# Altium schematic sheet NU-PROG_ESP32_Panel.SchDoc(NU-PROG_ESP32_Panel)
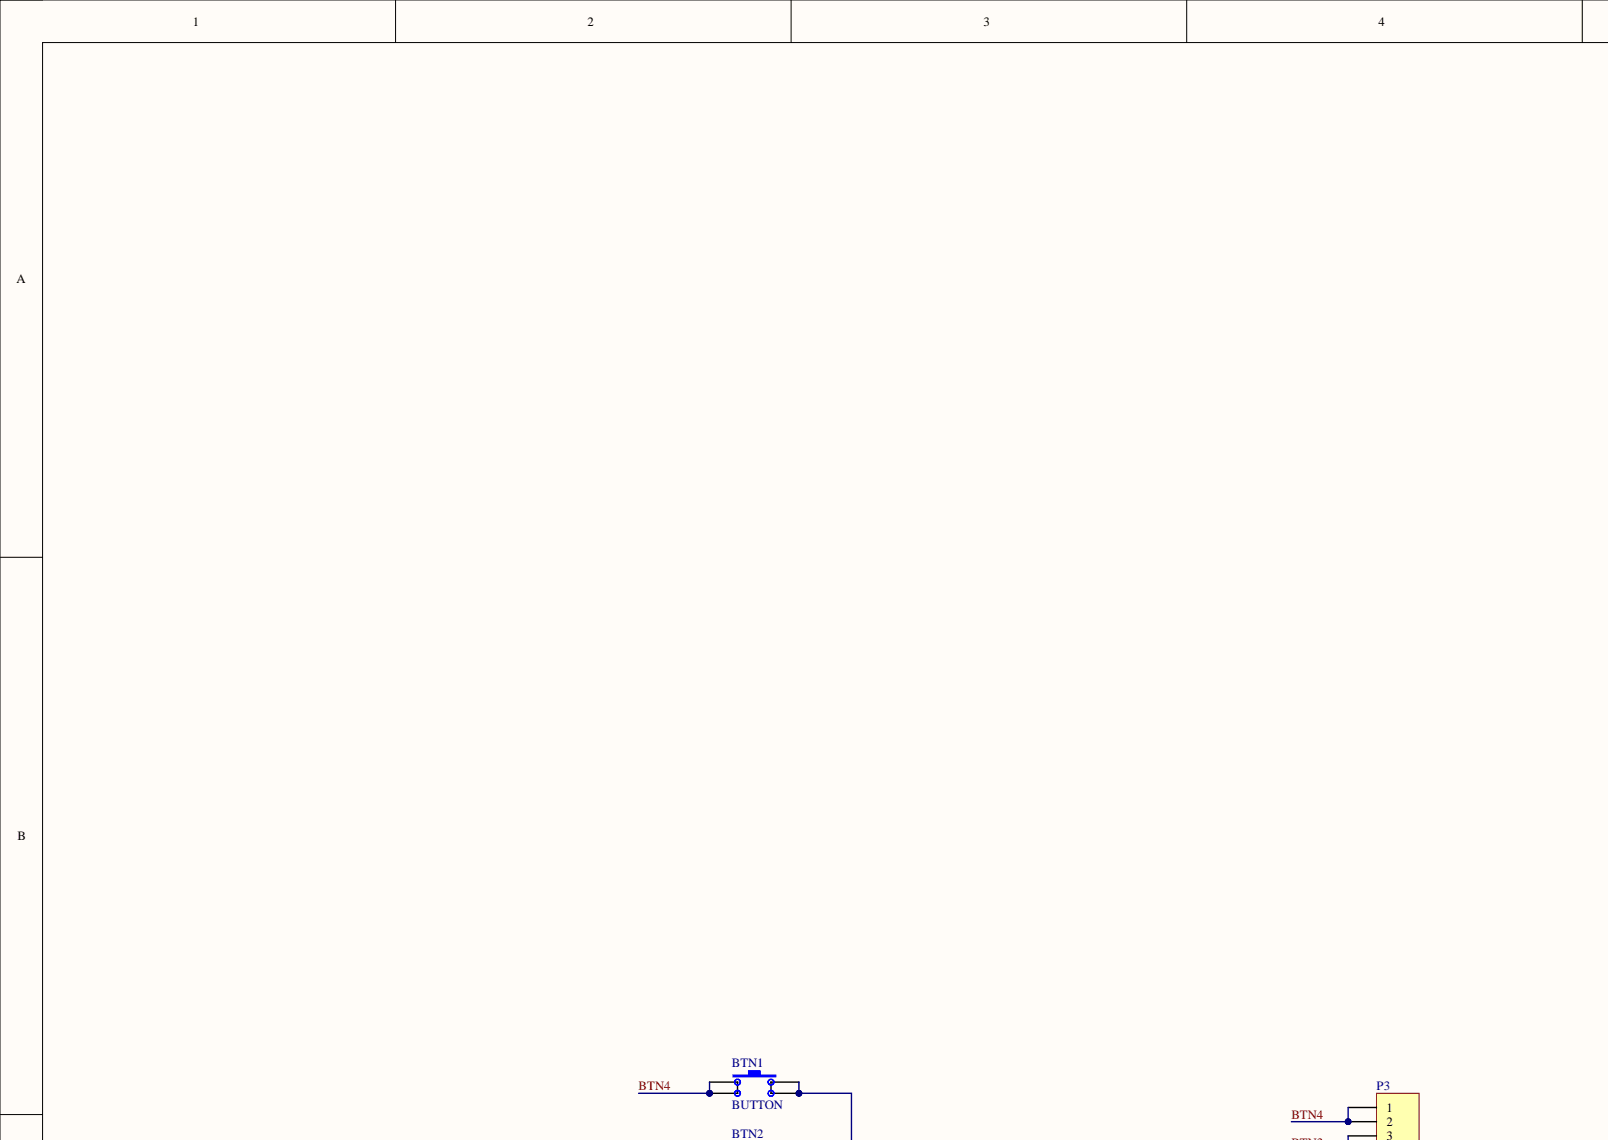
[diagram p0: NU-PROG_ESP32_Panel.SchDoc, top left]
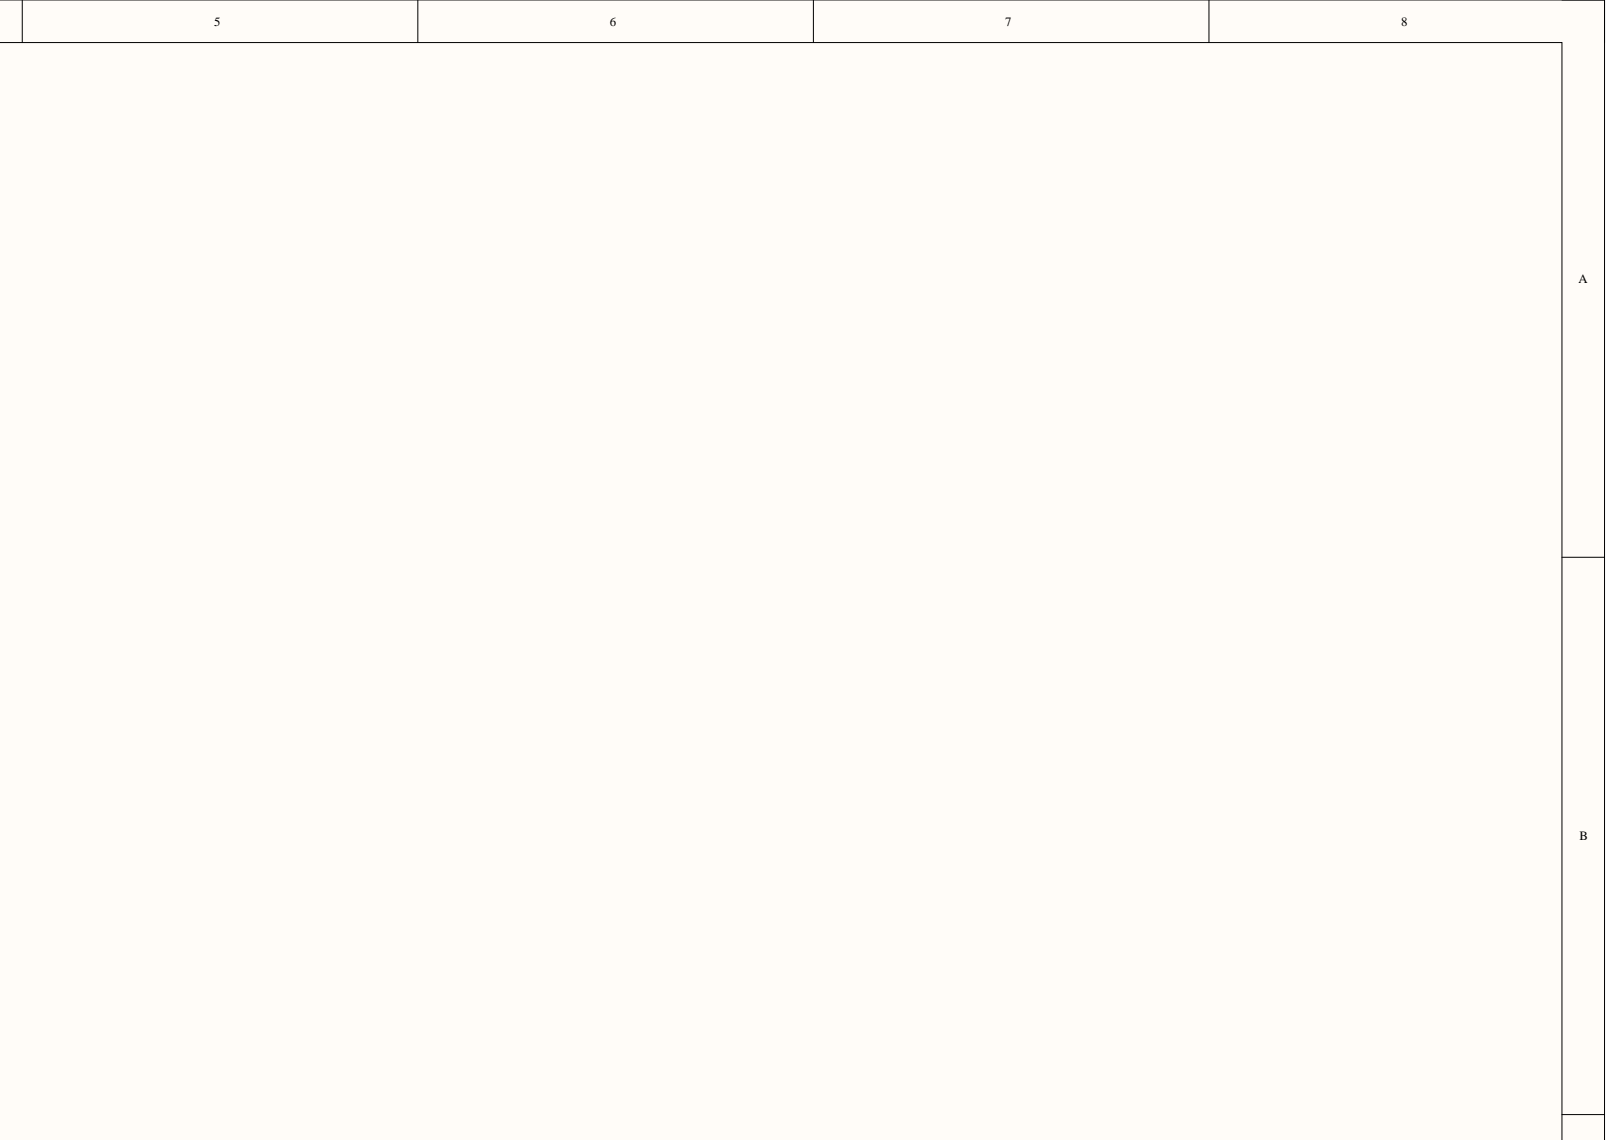
[diagram p1: NU-PROG_ESP32_Panel.SchDoc, top right]
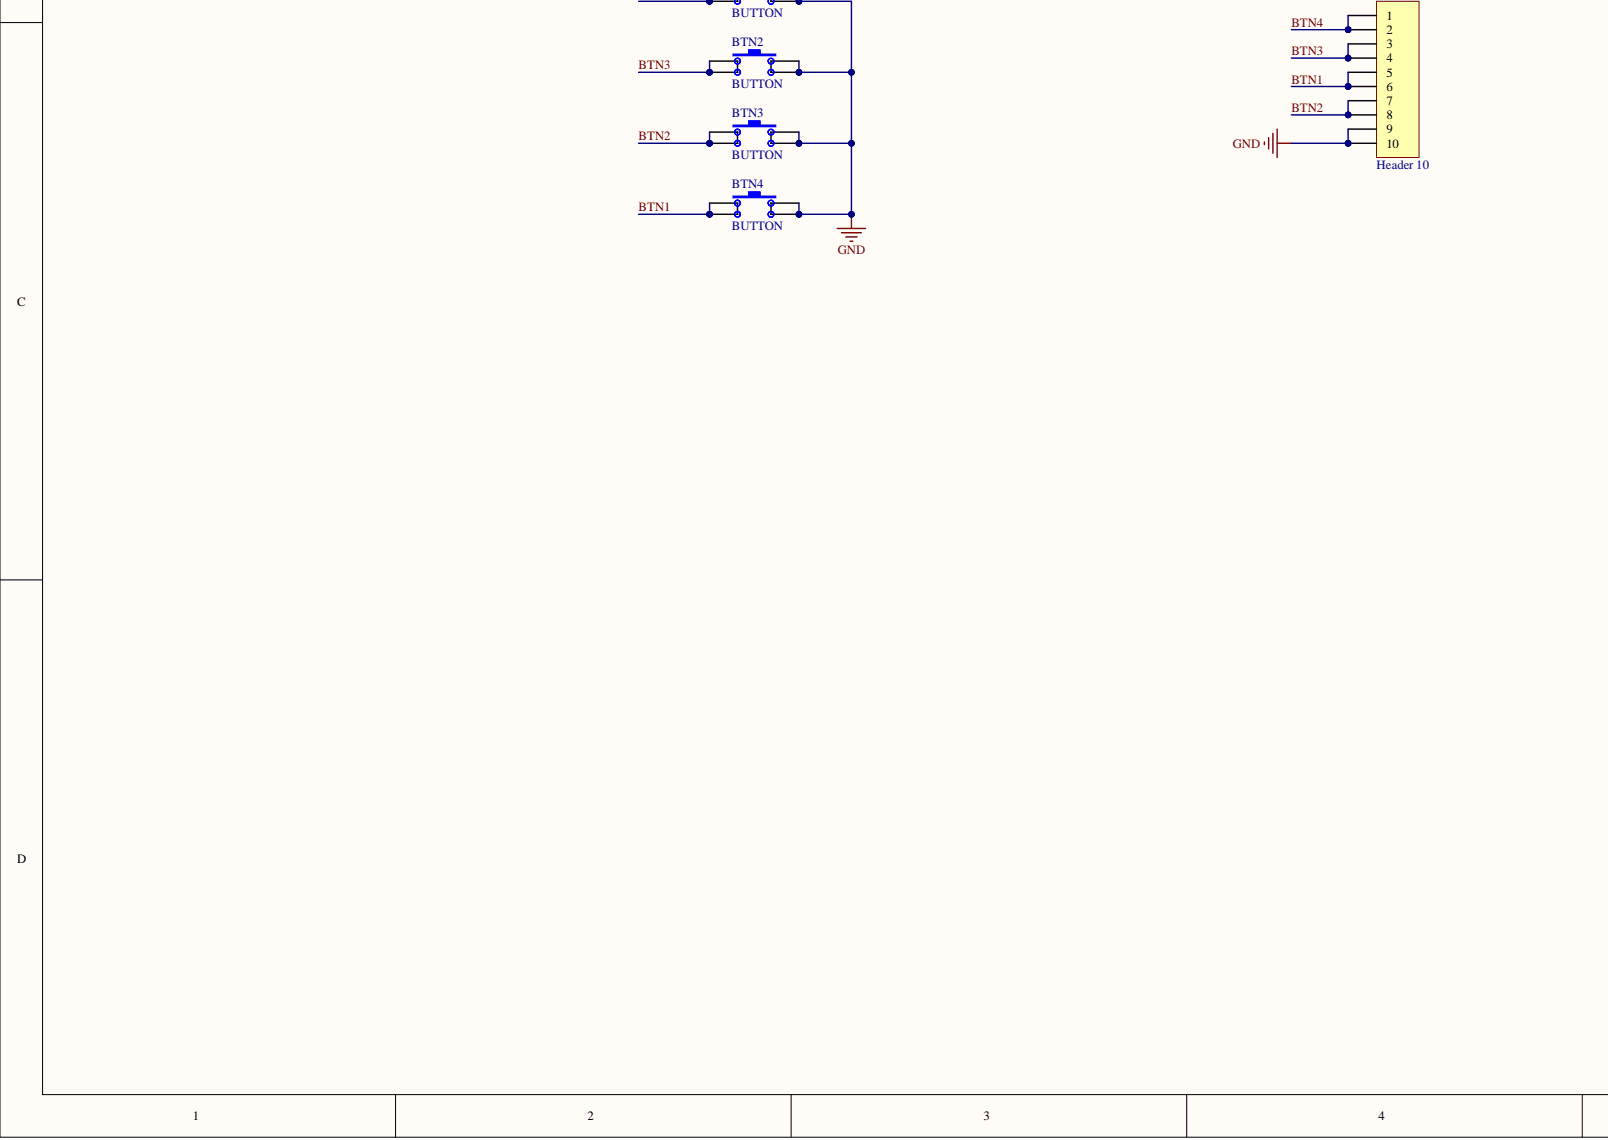
[diagram p2: NU-PROG_ESP32_Panel.SchDoc, bottom left]
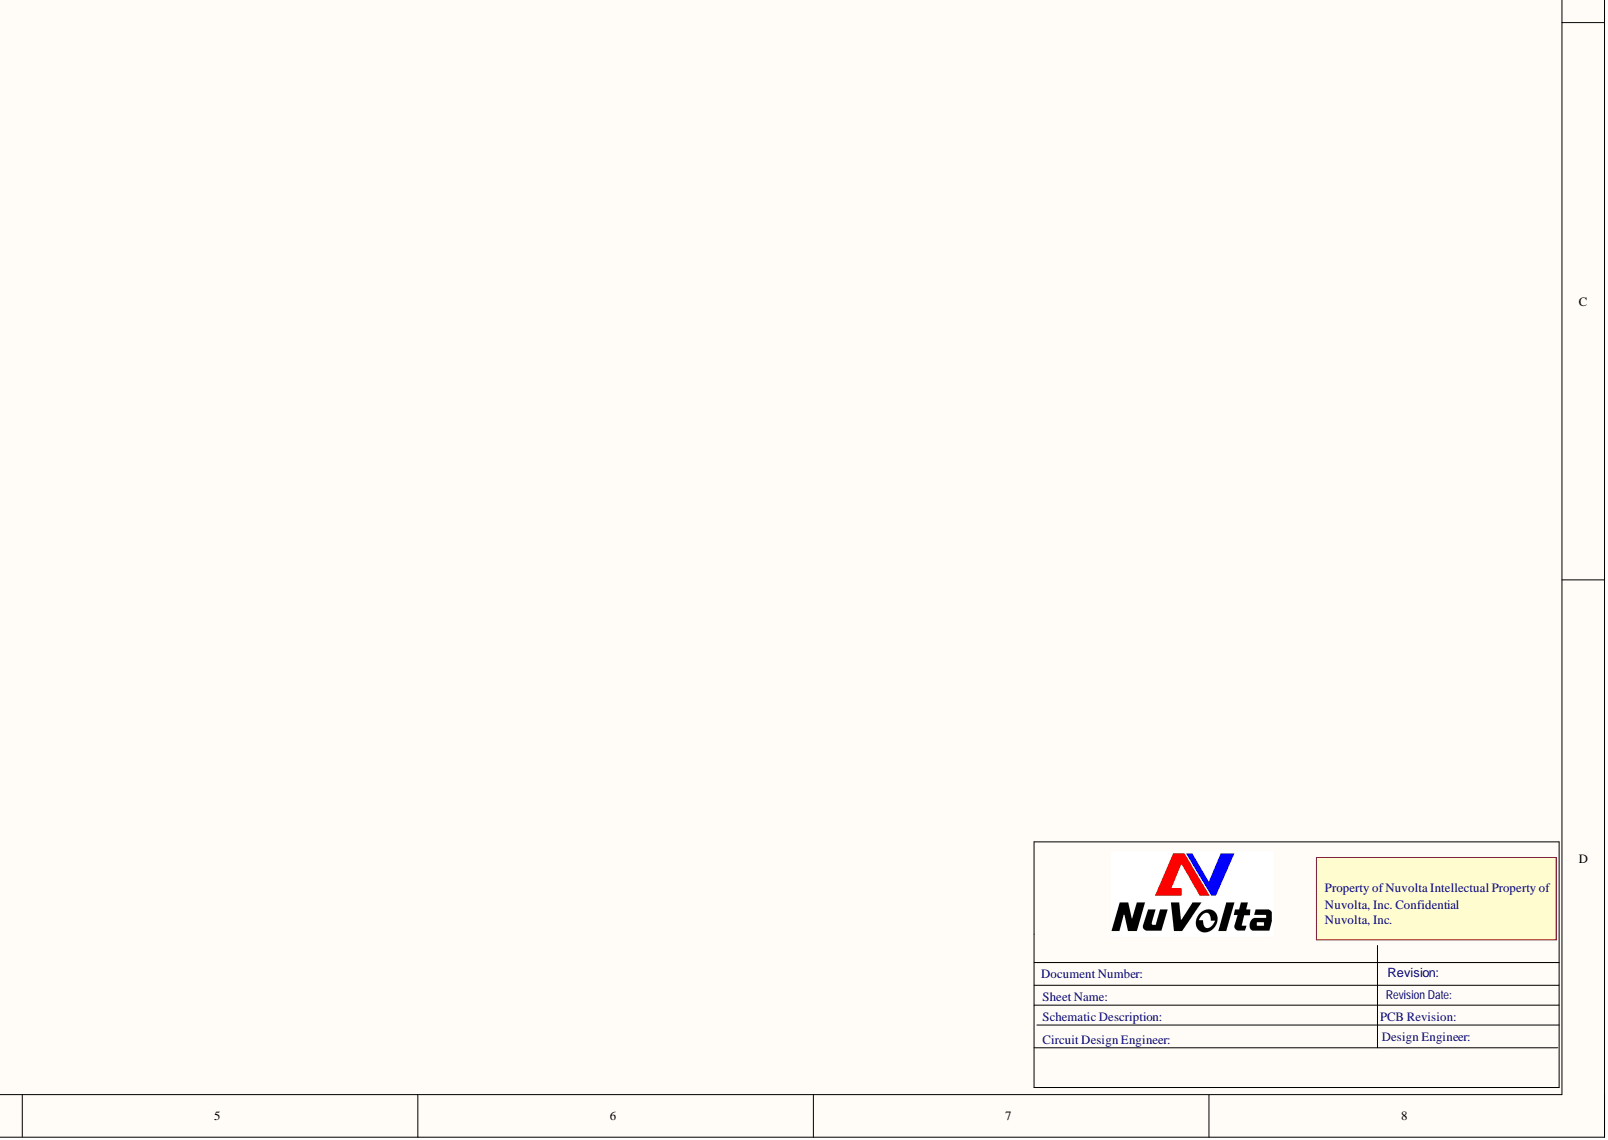
[diagram p3: NU-PROG_ESP32_Panel.SchDoc, bottom right]

## Parts
BTN1  BUTTON  [BUTTON]
BTN2  BUTTON  [BUTTON]
BTN3  BUTTON  [BUTTON]
BTN4  BUTTON  [BUTTON]
P3  Header 10  [HDR1X10_2]

## Nets
GND: BTN1.C BTN1.D BTN2.C BTN2.D BTN3.C BTN3.D BTN4.C BTN4.D P3.9 P3.10
BTN1: BTN4.A BTN4.B P3.5 P3.6
BTN2: BTN3.A BTN3.B P3.7 P3.8
BTN3: BTN2.A BTN2.B P3.3 P3.4
BTN4: BTN1.A BTN1.B P3.1 P3.2

## Library data of the parts
BTN1-BTN4 = BUTTON: Switch; Component Kind: Standard; LatestRevisionDate: 17-Jul-2002; LatestRevisionNote: Re-released for DXP Platform.; PackageDescription: Switch; 2 Leads; PackageReference: SPST-2; Published: 24-Mar-1999; Library Name: NU-PROG_ESP32.SchLib; Publisher: Altium Limited
P3 = Header 10: Header, 10-Pin; Component Kind: Standard; LatestRevisionDate: 17-Jul-2002; LatestRevisionNote: Re-released for DXP Platform.; Library Name: Miscellaneous Connectors.IntLib; Signal Integrity: Connector; Publisher: Altium Limited
